# Altium schematic sheet 1指纹锁_主控.SchDoc(1指纹锁_主控)
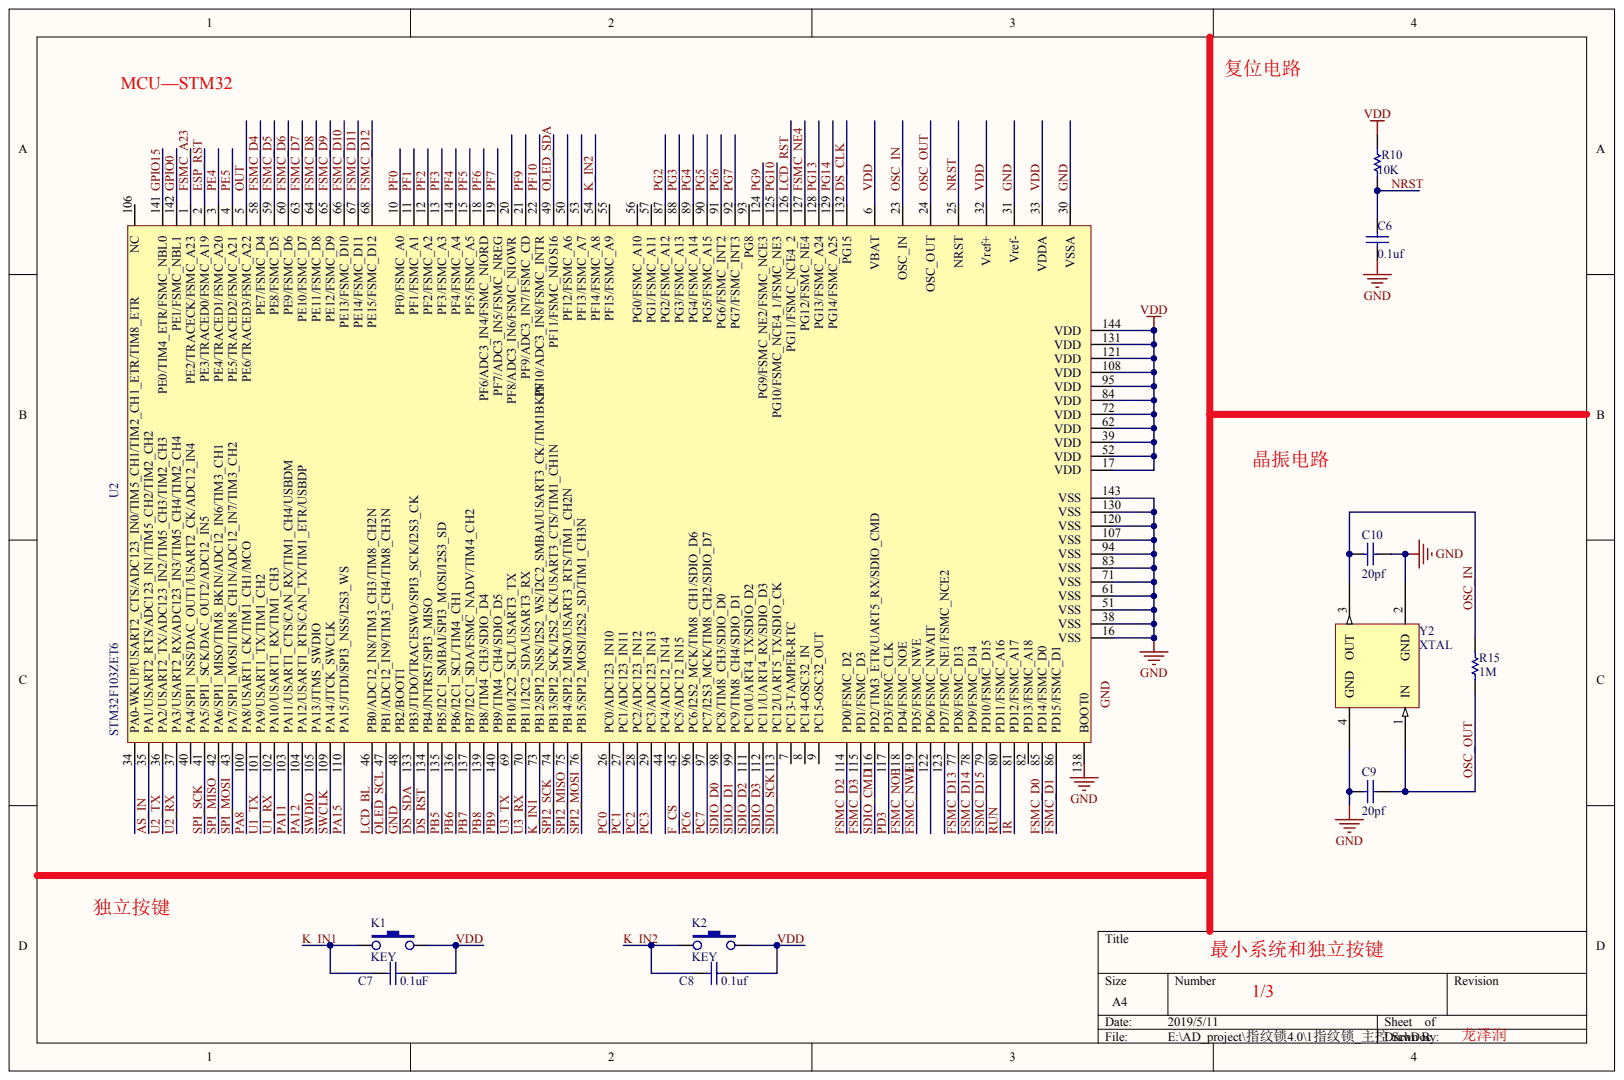
[diagram p0: 1指纹锁_主控.SchDoc]

## Parts
C6  值: 0.1uf  (hidden comment: Cap 1)
C7  值: 0.1uF  (hidden comment: Cap 1)
C8  值: 0.1uf  (hidden comment: Cap 1)
C9  值: 20pf  (hidden comment: Cap 1)
C10  值: 20pf  (hidden comment: Cap 1)
K1  KEY
K2  KEY
R10  值: 10K  (hidden comment: Res 1)
R15  值: 1M  (hidden comment: Res 1)
U2  STM32F103ZET6
Y2  XTAL

## Nets
AS_IN: U2.35(PA1/USART2_RTS/ADC123_IN1/TIM5_CH2/TIM2_CH2)
DS_CLK: U2.132(PG15)
DS_RST: U2.134(PB4/JNTRST/SPI3_MISO)
DS_SDA: U2.133(PB3/JTDO/TRACESWO/SPI3_SCK/I2S3_CK)
ESP_RST: U2.2(PE3/TRACED0/FSMC_A19)
F_CS: U2.45(PC5/ADC12_IN15)
FSMC_A23: U2.1(PE2/TRACECK/FSMC_A23)
FSMC_D0: U2.85(PD14/FSMC_D0)
FSMC_D1: U2.86(PD15/FSMC_D1)
FSMC_D2: U2.114(PD0/FSMC_D2)
FSMC_D3: U2.115(PD1/FSMC_D3)
FSMC_D4: U2.58(PE7/FSMC_D4)
FSMC_D5: U2.59(PE8/FSMC_D5)
FSMC_D6: U2.60(PE9/FSMC_D6)
FSMC_D7: U2.63(PE10/FSMC_D7)
FSMC_D8: U2.64(PE11/FSMC_D8)
FSMC_D9: U2.65(PE12/FSMC_D9)
FSMC_D10: U2.66(PE13/FSMC_D10)
FSMC_D11: U2.67(PE14/FSMC_D11)
FSMC_D12: U2.68(PE15/FSMC_D12)
FSMC_D13: U2.77(PD8/FSMC_D13)
FSMC_D14: U2.78(PD9/FSMC_D14)
FSMC_D15: U2.79(PD10/FSMC_D15)
FSMC_NE4: U2.127(PG12/FSMC_NE4)
FSMC_NOE: U2.118(PD4/FSMC_NOE)
FSMC_NWE: U2.119(PD5/FSMC_NWE)
GND: C6.1 C9.2 C10.1 U2.16(VSS) U2.30(VSSA) U2.31(Vref-) U2.38(VSS) U2.48(PB2/BOOT1) U2.51(VSS) U2.61(VSS) U2.71(VSS) U2.83(VSS) U2.94(VSS) U2.107(VSS) U2.120(VSS) U2.130(VSS) U2.138(BOOT0) U2.143(VSS) Y2.2(GND) Y2.4(GND)
GPIO0: U2.142(PE1/FSMC_NBL1)
GPIO15: U2.141(PE0/TIM4_ETR/FSMC_NBL0)
IR: U2.81(PD12/FSMC_A17)
K_IN1: C7.1 K1.1 U2.73(PB12/SPI2_NSS/I2S2_WS/I2C2_SMBAI/USART3_CK/TIM1BKIN)
K_IN2: C8.2 K2.1 U2.54(PF14/FSMC_A8)
LCD_BL: U2.46(PB0/ADC12_IN8/TIM3_CH3/TIM8_CH2N)
LCD_RST: U2.126(PG11/FSMC_NCE4_2)
NRST: C6.2 R10.1 U2.25(NRST)
OLED_SCL: U2.47(PB1/ADC12_IN9/TIM3_CH4/TIM8_CH3N)
OLED_SDA: U2.49(PF11/FSMC_NIOS16)
OSC_IN: C10.2 R15.1 U2.23(OSC_IN) Y2.3(OUT)
OSC_OUT: C9.1 R15.2 U2.24(OSC_OUT) Y2.1(IN)
OUT: U2.5(PE6/TRACED3/FSMC_A22)
PA8: U2.100(PA8/USART1_CK/TIM1_CH1/MCO)
PA11: U2.103(PA11/USART1_CTS/CAN_RX/TIM1_CH4/USBDM)
PA12: U2.104(PA12/USART1_RTS/CAN_TX/TIM1_ETR/USBDP)
PA15: U2.110(PA15/JTDI/SPI3_NSS/I2S3_WS)
PB5: U2.135(PB5/I2C1_SMBAI/SPI3_MOSI/I2S3_SD)
PB6: U2.136(PB6/I2C1_SCL/TIM4_CH1)
PB7: U2.137(PB7/I2C1_SDA/FSMC_NADV/TIM4_CH2)
PB8: U2.139(PB8/TIM4_CH3/SDIO_D4)
PB9: U2.140(PB9/TIM4_CH4/SDIO_D5)
PC0: U2.26(PC0/ADC123_IN10)
PC1: U2.27(PC1/ADC123_IN11)
PC2: U2.28(PC2/ADC123_IN12)
PC3: U2.29(PC3/ADC123_IN13)
PC6: U2.96(PC6/I2S2_MCK/TIM8_CH1/SDIO_D6)
PC7: U2.97(PC7/I2S3_MCK/TIM8_CH2/SDIO_D7)
PD3: U2.117(PD3/FSMC_CLK)
PE4: U2.3(PE4/TRACED1/FSMC_A20)
PE5: U2.4(PE5/TRACED2/FSMC_A21)
PF0: U2.10(PF0/FSMC_A0)
PF1: U2.11(PF1/FSMC_A1)
PF2: U2.12(PF2/FSMC_A2)
PF3: U2.13(PF3/FSMC_A3)
PF4: U2.14(PF4/FSMC_A4)
PF5: U2.15(PF5/FSMC_A5)
PF6: U2.18(PF6/ADC3_IN4/FSMC_NIORD)
PF7: U2.19(PF7/ADC3_IN5/FSMC_NREG)
PF9: U2.21(PF9/ADC3_IN7/FSMC_CD)
PF10: U2.22(PF10/ADC3_IN8/FSMC_INTR)
PG2: U2.87(PG2/FSMC_A12)
PG3: U2.88(PG3/FSMC_A13)
PG4: U2.89(PG4/FSMC_A14)
PG5: U2.90(PG5/FSMC_A15)
PG6: U2.91(PG6/FSMC_INT2)
PG7: U2.92(PG7/FSMC_INT3)
PG9: U2.124(PG9/FSMC_NE2/FSMC_NCE3)
PG10: U2.125(PG10/FSMC_NCE4_1/FSMC_NE3)
PG13: U2.128(PG13/FSMC_A24)
PG14: U2.129(PG14/FSMC_A25)
RUN: U2.80(PD11/FSMC_A16)
SDIO_CMD: U2.116(PD2/TIM3_ETR/UART5_RX/SDIO_CMD)
SDIO_D0: U2.98(PC8/TIM8_CH3/SDIO_D0)
SDIO_D1: U2.99(PC9/TIM8_CH4/SDIO_D1)
SDIO_D2: U2.111(PC10/UART4_TX/SDIO_D2)
SDIO_D3: U2.112(PC11/UART4_RX/SDIO_D3)
SDIO_SCK: U2.113(PC12/UART5_TX/SDIO_CK)
SPI2_MISO: U2.75(PB14/SPI2_MISO/USART3_RTS/TIM1_CH2N)
SPI2_MOSI: U2.76(PB15/SPI2_MOSI/I2S2_SD/TIM1_CH3N)
SPI2_SCK: U2.74(PB13/SPI2_SCK/I2S2_CK/USART3_CTS/TIM1_CH1N)
SPI_MISO: U2.42(PA6/SPI1_MISO/TIM8_BKIN/ADC12_IN6/TIM3_CH1)
SPI_MOSI: U2.43(PA7/SPI1_MOSI/TIM8_CH1N/ADC12_IN7/TIM3_CH2)
SPI_SCK: U2.41(PA5/SPI1_SCK/DAC_OUT2/ADC12_IN5)
SWCLK: U2.109(PA14/JTCK_SWCLK)
SWDIO: U2.105(PA13/JTMS_SWDIO)
U1_RX: U2.102(PA10/USART1_RX/TIM1_CH3)
U1_TX: U2.101(PA9/USART1_TX/TIM1_CH2)
U2_RX: U2.37(PA3/USART2_RX/ADC123_IN3/TIM5_CH4/TIM2_CH4)
U2_TX: U2.36(PA2/USART2_TX/ADC123_IN2/TIM5_CH3/TIM2_CH3)
U3_RX: U2.70(PB11/I2C2_SDA/USART3_RX)
U3_TX: U2.69(PB10/I2C2_SCL/USART3_TX)
VDD: C7.2 C8.1 K1.3 K2.3 R10.2 U2.6(VBAT) U2.17(VDD) U2.32(Vref+) U2.33(VDDA) U2.39(VDD) U2.52(VDD) U2.62(VDD) U2.72(VDD) U2.84(VDD) U2.95(VDD) U2.108(VDD) U2.121(VDD) U2.131(VDD) U2.144(VDD)

Not connected: U2 7(PC13-TAMPER-RTC) 8(PC14-OSC32_IN) 9(PC15-OSC32_OUT) 20(PF8/ADC3_IN6/FSMC_NIOWR) 34(PA0-WKUP/USART2_CTS/ADC123_IN0/TIM5_CH1/TIM2_CH1_ETR/TIM8_ETR) 40(PA4/SPI1_NSS/DAC_OUT1/USART2_CK/ADC12_IN4) 44(PC4/ADC12_IN14) 50(PF12/FSMC_A6) 53(PF13/FSMC_A7) 55(PF15/FSMC_A9) 56(PG0/FSMC_A10) 57(PG1/FSMC_A11) 82(PD13/FSMC_A18) 93(PG8) 106(NC) 122(PD6/FSMC_NWAIT) 123(PD7/FSMC_NE1/FSMC_NCE2)
